# Altium schematic sheet Power_5V.SchDoc("Power 5V")
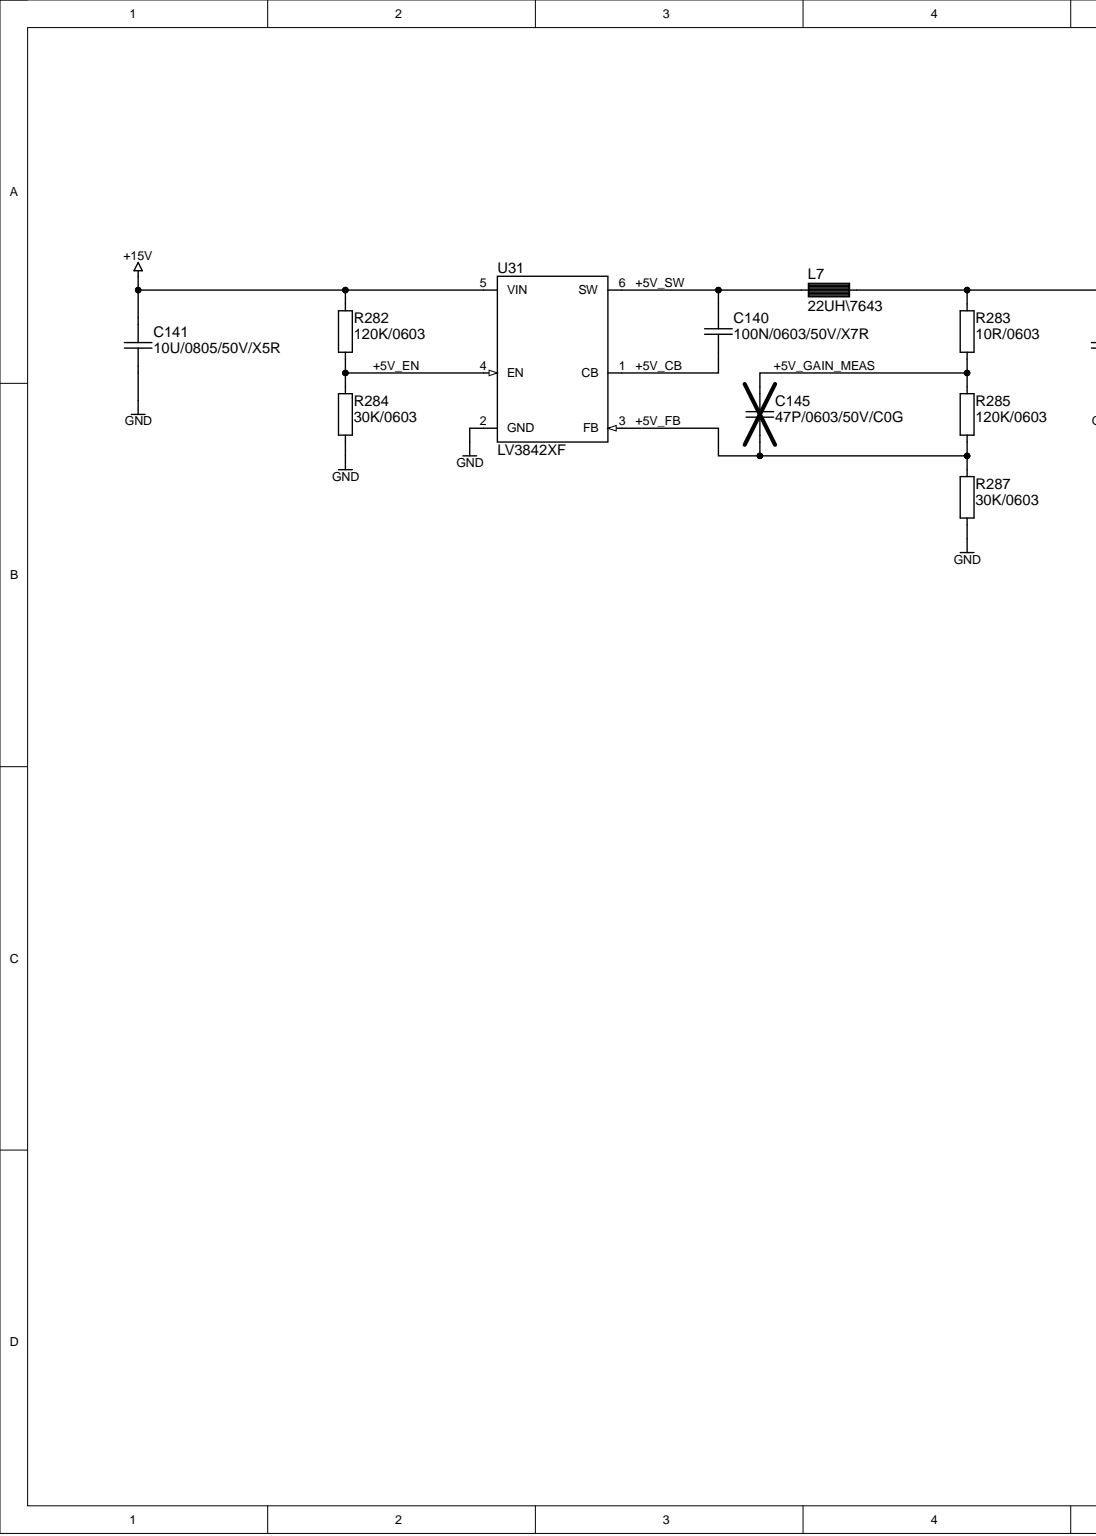
[diagram p0: Power_5V.SchDoc, left]
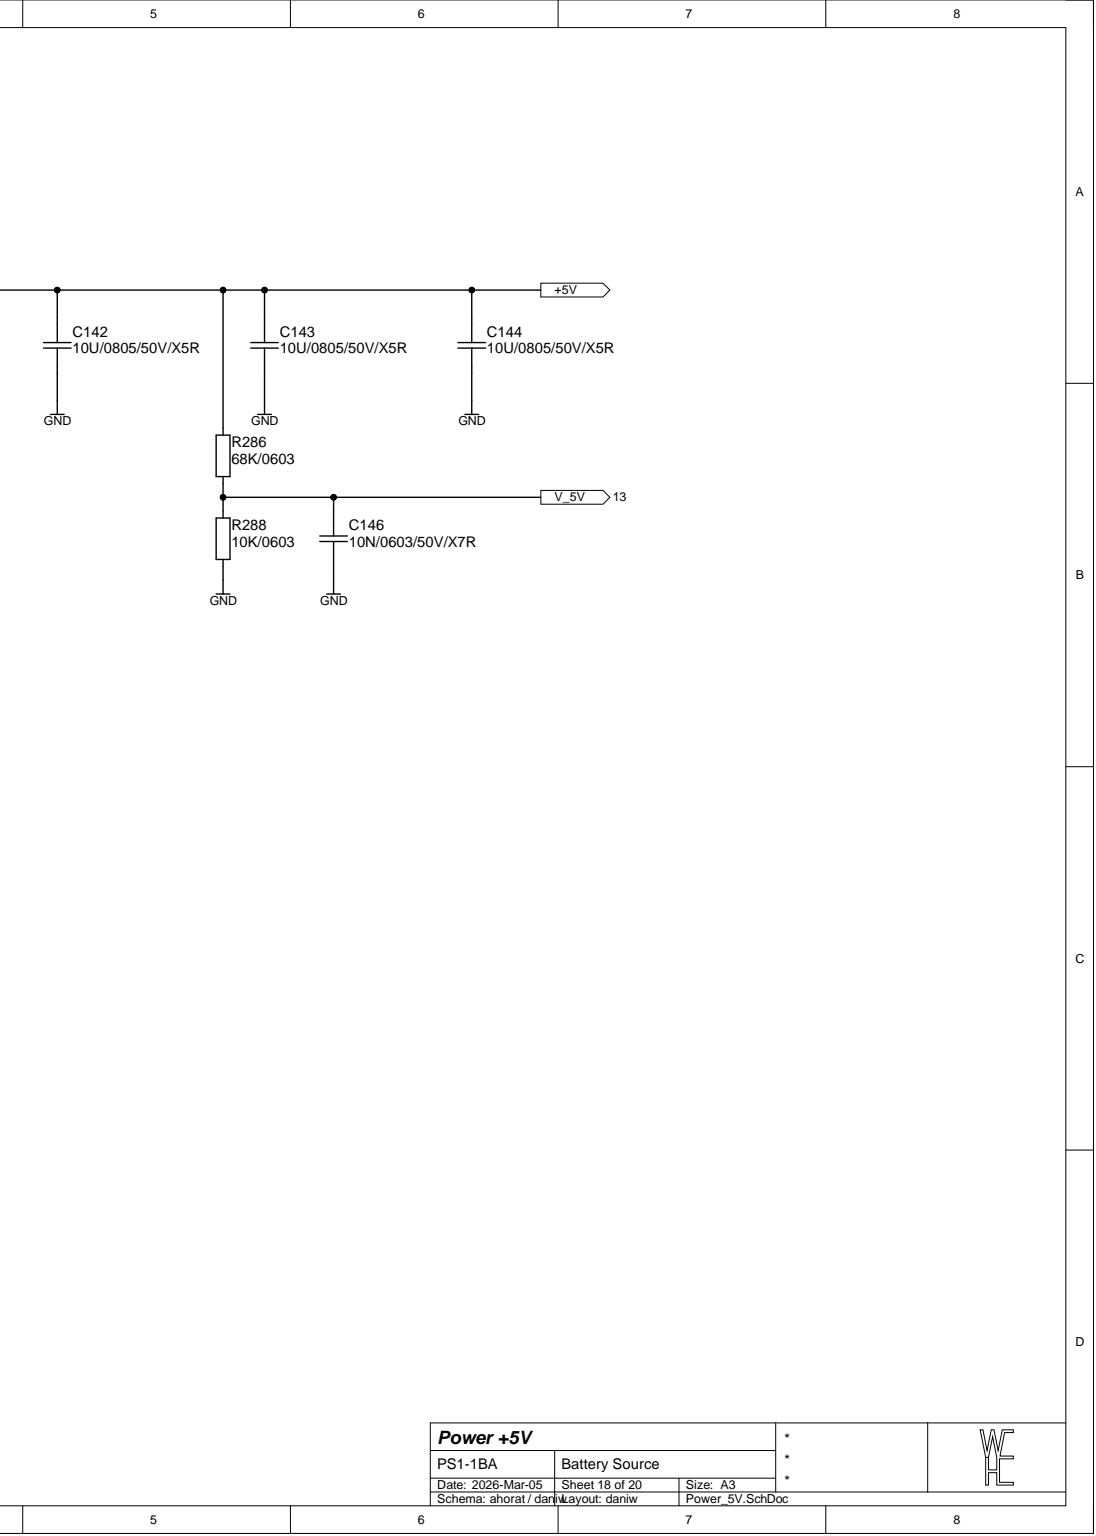
[diagram p1: Power_5V.SchDoc, right]

## Parts
C140  100N/0603/50V/X7R  [CAP0603_X7R]
C141  10U/0805/50V/X5R  [CAP0805_X7R]
C142  10U/0805/50V/X5R  [CAP0805_X7R]
C143  10U/0805/50V/X5R  [CAP0805_X7R]
C144  10U/0805/50V/X5R  [CAP0805_X7R]
C145  47P/0603/50V/C0G  [CAP0603_C0G]
C146  10N/0603/50V/X7R  [CAP0603_X7R]
L7  22UH\7643  [INDM7676X43]
R282  120K/0603  [R0603-0.40-WW]
R283  10R/0603  [R0603-0.40-WW]
R284  30K/0603  [R0603-0.40-WW]
R285  120K/0603  [R0603-0.40-WW]
R286  68K/0603  [R0603-0.40-WW]
R287  30K/0603  [R0603-0.40-WW]
R288  10K/0603  [R0603-0.40-WW]
U31  LV3842XF  [SOT2395P280X125-6-NW]

## Ports
+5V, V_5V

## Nets
+15V: C141.1 R282.1 U31.5(VIN)
GND: C141.2 C142.2 C143.2 C144.2 C146.2 R284.2 R287.2 R288.2 U31.2(GND)
+5V: C142.1 C143.1 C144.1 L7.2 R283.1 R286.1 ; port +5V
+5V_CB: C140.2 U31.1(CB)
+5V_EN: R282.2 R284.1 U31.4(EN)
+5V_FB: C145.2 R285.2 R287.1 U31.3(FB)
+5V_GAIN_MEAS: C145.1 R283.2 R285.1
+5V_SW: C140.1 L7.1 U31.6(SW)
V_5V: C146.1 R286.2 R288.1 ; port V_5V

## Library data of the parts
C140 = 100N/0603/50V/X7R: CAP SM 0603 100nF 50V X7R; Manufacturer: GENERIC; Manufacturer 1: YAGEO; Manufacturer Part Number 1: CC0603KPX7R9BB104; Absolute Value: 1E-7; Database Table Name: C$; Eurocircuits GPN: GPC0603104; Component Kind: Standard; HelpURL: https://www.yageo.com/upload/media/product/app/datasheet/mlcc/upy-gphc_x7r_6_3v-to-250v.pdf; JLC Type: Basic; Manufacturer 2: YAGEO; Manufacturer Part Number 2: CC0603MRX7R9BB104; Manufacturer Part Number 3: CC0603KRX7R9BB104; Package: 0603; Part Number: 100N/0603/50V/X7R; Supplier 1: Digi-Key; Supplier 2: Digi-Key; Supplier 3: LCSC; Supplier Part Number 1: 311-3567-1-ND; Supplier Part Number 2: 311-4110-1-ND; Supplier Part Number 3: C14663; Value: 100nF; Library Name: daniw_altium_lib.DbLib
C141-C144 = 10U/0805/50V/X5R: CAP SM 0805 10uF 50V X5R; Manufacturer: GENERIC; Manufacturer 1: Murata Electronics; Manufacturer Part Number 1: GRM21BR61H106KE43K; Absolute Value: 1E-5; Database Table Name: C$; Eurocircuits GPN: GPN0805106-50-X5R; Component Kind: Standard; HelpURL: https://search.murata.co.jp/Ceramy/image/img/A01X/G101/ENG/GRM21BR61H106KE43-01A.pdf; JLC Type: Basic; Manufacturer Part Number 3: GRM21BR61H106KE43L; Package: 0805; Part Number: 10U/0805/50V/X5R; Supplier 1: Digi-Key; Supplier 3: LCSC; Supplier Part Number 1: 490-GRM21BR61H106KE43KCT-ND; Supplier Part Number 3: C440198; Value: 10uF; Library Name: daniw_altium_lib.DbLib
C145 = 47P/0603/50V/C0G: CAP SM 0603 47pF 50V C0G; Manufacturer: GENERIC; Manufacturer 1: YAGEO; Manufacturer Part Number 1: CC0603JRNPO9BN470; Absolute Value: 4.7E-11; Database Table Name: C$; Eurocircuits GPN: GPC0603470-C0G; Component Kind: Standard; HelpURL: https://www.yageo.com/upload/media/product/productsearch/datasheet/mlcc/UPY-GP_NP0_16V-to-50V_18.pdf; JLC Type: Basic; Manufacturer 2: YAGEO; Manufacturer Part Number 2: CC0603KRNPO9BN470; Manufacturer Part Number 3: CL10C470JB8NNNC; Package: 0603; Part Number: 47P/0603/50V/C0G; Supplier 1: Digi-Key; Supplier 2: Digi-Key; Supplier 3: LCSC; Supplier Part Number 1: 311-1065-1-ND; Supplier Part Number 2: 311-4037-1-ND; Supplier Part Number 3: C1671; Value: 47pF; Library Name: daniw_altium_lib.DbLib
C146 = 10N/0603/50V/X7R: CAP SM 0603 10nF 50V X7R; Manufacturer: GENERIC; Manufacturer 1: YAGEO; Manufacturer Part Number 1: CC0603KPX7R9BB103; Absolute Value: 1E-8; Database Table Name: C$; Eurocircuits GPN: GPC0603103; Component Kind: Standard; HelpURL: https://www.yageo.com/upload/media/product/app/datasheet/mlcc/upy-gphc_x7r_6_3v-to-250v.pdf; Manufacturer 2: YAGEO; Manufacturer Part Number 2: CC0603KRX7R9BB103; Manufacturer Part Number 3: CC0603KRX7R9BB103; Package: 0603; Part Number: 10N/0603/50V/X7R; Supplier 1: Digi-Key; Supplier 2: Digi-Key; Supplier 3: LCSC; Supplier Part Number 1: 311-1572-1-ND; Supplier Part Number 2: 311-1085-1-ND; Supplier Part Number 3: C100042; Value: 10nF; Library Name: daniw_altium_lib.DbLib
L7 = 22UH\7643: FIXED IND 22UH 1.75A 92.5MOHM SM; Manufacturer: Eaton - Electronics Division; Manufacturer Part Number 1: DR74-220-R; Absolute Value: 2.2E-5; Database Table Name: L$; Component Kind: Standard; HelpURL: https://www.eaton.com/content/dam/eaton/products/electronic-components/resources/data-sheet/eaton-dr-high-power-density-high-efficiency-shielded-drum-core-power-inductors-data-sheet.pdf; Manufacturer 1: Eaton - Electronics Division; Manufacturer 3: SXN(Shun Xiang Nuo Elec); Manufacturer Part Number 3: SMDRI74-220M; Package: 7643; Part Number: 22UH\7643; Supplier 1: Digi-Key; Supplier 3: LCSC; Supplier Part Number 1: 513-1390-1-ND; Supplier Part Number 3: C164083; Value: 22UH; Library Name: daniw_altium_lib.DbLib
R282 R285 = 120K/0603: RES SM 0603 120K; Manufacturer: GENERIC; Manufacturer 1: YAGEO; Manufacturer Part Number 1: RC0603FR-13120KL; Absolute Value: 120000; Database Table Name: R$; Eurocircuits GPN: GPR0603120K; Component Kind: Standard; HelpURL: https://www.yageogroup.com/content/datasheet/asset/file/PYU-RC_GROUP_51_ROHS_L; JLC Type: Basic; Manufacturer 2: YAGEO; Manufacturer 3: UNI-ROYAL(Uniroyal Elec); Manufacturer Part Number 2: RC0603FR-10120KL; Manufacturer Part Number 3: 0603WAF1203T5E; Package: 0603; Part Number: 120K/0603; Supplier 1: Digi-Key; Supplier 2: Digi-Key; Supplier 3: LCSC; Supplier Part Number 1: 13-RC0603FR-13120KLCT-ND; Supplier Part Number 2: 13-RC0603FR-10120KLCT-ND; Supplier Part Number 3: C25808; Value: 120K; Library Name: daniw_altium_lib.DbLib
R283 = 10R/0603: RES SM 0603 10R; Manufacturer: GENERIC; Manufacturer 1: YAGEO; Manufacturer Part Number 1: RC0603FR-1310RL; Absolute Value: 10; Database Table Name: R$; Eurocircuits GPN: GPR060310R; Component Kind: Standard; HelpURL: https://www.yageogroup.com/content/datasheet/asset/file/PYU-RC_GROUP_51_ROHS_L; JLC Type: Basic; Manufacturer 2: YAGEO; Manufacturer 3: UNI-ROYAL; Manufacturer Part Number 2: RC0603FR-1010RL; Manufacturer Part Number 3: 0603WAF100JT5E; Package: 0603; Part Number: 10R/0603; Supplier 1: Digi-Key; Supplier 2: Digi-Key; Supplier 3: LCSC; Supplier Part Number 1: 13-RC0603FR-1310RLCT-ND; Supplier Part Number 2: 13-RC0603FR-1010RLCT-ND; Supplier Part Number 3: C22859; Value: 10R; Library Name: daniw_altium_lib.DbLib
R284 R287 = 30K/0603: RES SM 0603 30K; Manufacturer: GENERIC; Manufacturer 1: YAGEO; Manufacturer Part Number 1: RC0603FR-1330KL; Absolute Value: 30000; Database Table Name: R$; Eurocircuits GPN: GPR060330K; Component Kind: Standard; HelpURL: https://www.yageogroup.com/content/datasheet/asset/file/PYU-RC_GROUP_51_ROHS_L; JLC Type: Basic; Manufacturer 2: YAGEO; Manufacturer 3: UNI-ROYAL(Uniroyal Elec); Manufacturer Part Number 2: RC0603FR-1030KL; Manufacturer Part Number 3: 0603WAF3002T5E; Package: 0603; Part Number: 30K/0603; Supplier 1: Digi-Key; Supplier 2: Digi-Key; Supplier 3: LCSC; Supplier Part Number 1: 13-RC0603FR-1330KLCT-ND; Supplier Part Number 2: 13-RC0603FR-1030KLCT-ND; Supplier Part Number 3: C22984; Value: 30K; Library Name: daniw_altium_lib.DbLib
R286 = 68K/0603: RES SM 0603 68K; Manufacturer: GENERIC; Manufacturer 1: YAGEO; Manufacturer Part Number 1: RC0603FR-1368KL; Absolute Value: 68000; Database Table Name: R$; Eurocircuits GPN: GPR060368K; Component Kind: Standard; HelpURL: https://www.yageogroup.com/content/datasheet/asset/file/PYU-RC_GROUP_51_ROHS_L; JLC Type: Basic; Manufacturer 2: YAGEO; Manufacturer 3: YAGEO; Manufacturer Part Number 2: RC0603FR-1068KL; Manufacturer Part Number 3: RC0603FR-0768KL; Package: 0603; Part Number: 68K/0603; Supplier 1: Digi-Key; Supplier 2: Digi-Key; Supplier 3: LCSC; Supplier Part Number 1: 13-RC0603FR-1368KLCT-ND; Supplier Part Number 2: 13-RC0603FR-1068KLCT-ND; Supplier Part Number 3: C114633; Value: 68K; Library Name: daniw_altium_lib.DbLib
R288 = 10K/0603: RES SM 0603 10K; Manufacturer: GENERIC; Manufacturer 1: YAGEO; Manufacturer Part Number 1: RC0603FR-1310KL; Absolute Value: 10000; Database Table Name: R$; Eurocircuits GPN: GPR060310K; Component Kind: Standard; HelpURL: https://www.yageogroup.com/content/datasheet/asset/file/PYU-RC_GROUP_51_ROHS_L; JLC Type: Basic; Manufacturer 2: YAGEO; Manufacturer 3: UNI-ROYAL(Uniroyal Elec); Manufacturer Part Number 2: RC0603FR-1010KL; Manufacturer Part Number 3: 0603WAF1002T5E; Package: 0603; Part Number: 10K/0603; Supplier 1: Digi-Key; Supplier 2: Digi-Key; Supplier 3: LCSC; Supplier Part Number 1: 13-RC0603FR-1310KLCT-ND; Supplier Part Number 2: 13-RC0603FR-1010KLCT-ND; Supplier Part Number 3: C25804; Value: 10K; Library Name: daniw_altium_lib.DbLib
U31 = LV3842XF: 4-V to 36-V, 600-mA, Synchronous Step-Down Converter; Manufacturer: Texas Instruments; Manufacturer Part Number 1: LV3842XFDBVR; Database Table Name: Integrated$; Component Kind: Standard; HelpURL: https://www.ti.com/lit/ds/symlink/lv3842.pdf; Manufacturer 1: Texas Instruments; Manufacturer 3: Texas Instruments; Manufacturer Part Number 3: LV3842XFDBVR; Package: SOT23; Part Number: LV3842XF; Supplier 1: Digi-Key; Supplier 3: LCSC; Supplier Part Number 1: 296-LV3842XFDBVR-ND; Supplier Part Number 3: C22428295; Library Name: daniw_altium_lib.DbLib
